# Altium schematic sheet mount_board.SchDoc
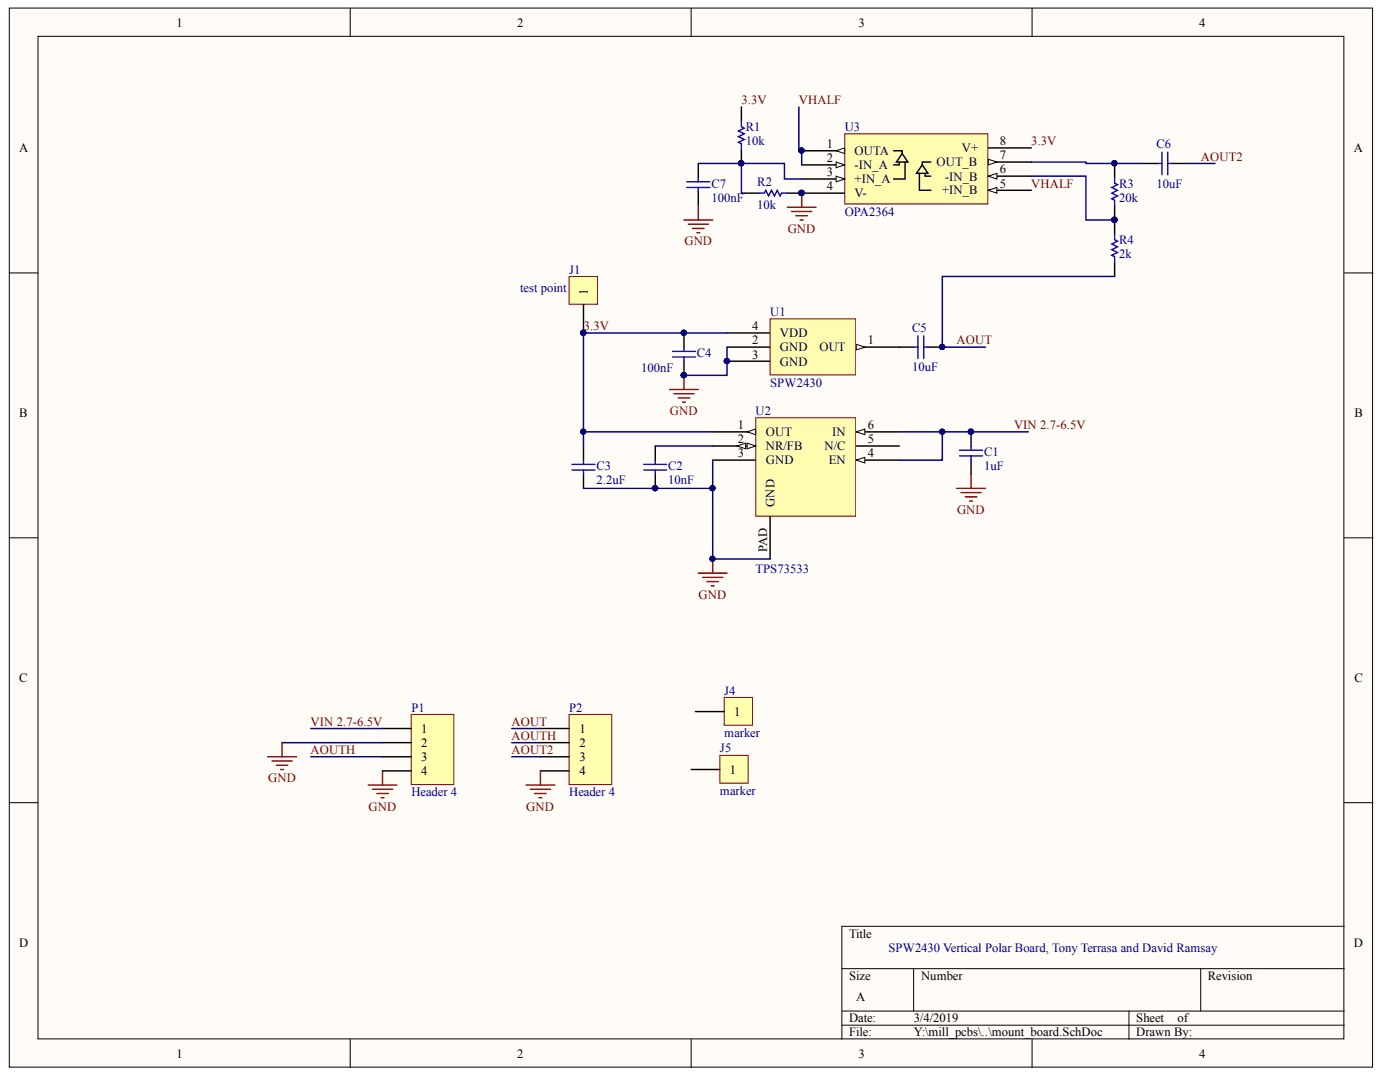
[diagram p0: mount_board.SchDoc]

## Parts
C1  1uF  [0603C]
C2  10nF  [0603C]
C3  2.2uF  [0603C]
C4  100nF  [0603C]
C5  10uF  [0603C]
C6  10uF  [0603C]
C7  100nF  [0603C]
J1  test point  [TEST POINT SMD SMALL]
J4  marker  [TEST POINT SMD SUPER SMALL]
J5  marker  [TEST POINT SMD SUPER SMALL]
P1  Header 4  [HDR1X4]
P2  Header 4  [HDR1X4]
R1  10k  [0603R]
R2  10k  [0603R]
R3  20k  [0603R]
R4  2k  [0603R]
U1  SPW2430  [MEMS MIC 4-pad]
U2  TPS73533  [SON_6LEAD_TI_TPS]
U3  OPA2364  [SOIC8]

## Nets
GND: C1.1 C2.1 C3.1 C4.1 C7.1 P1.2 P1.4 P2.4 R2.1 U1.2(GND) U1.3(GND) U2.3(GND) U2.PAD(GND) U3.4(V-)
3.3V: C3.2 C4.2 J1.1 R1.1 U1.4(VDD) U2.1(OUT) U3.8(V+)
AOUT: C5.1 P2.1 R4.2
AOUT2: C6.1 P2.3
AOUTH: P1.3 P2.2
VHALF: U3.1(OUTA) U3.2(-IN_A) U3.5(+IN_B)
VIN 2.7-6.5V: C1.2 P1.1 U2.4(EN) U2.6(IN)
NetC2_2: C2.2 U2.2(NR/FB)
NetC5_2: C5.2 U1.1(OUT)
NetC6_2: C6.2 R3.1 U3.7(OUT_B)
NetC7_2: C7.2 R1.2 R2.2 U3.3(+IN_A)
NetR3_2: R3.2 R4.1 U3.6(-IN_B)

Not connected: J4 1; J5 1; U2 5(N/C)

## Library data of the parts
C1-C7 = Capacitor: Component Kind: Standard; Simulation: CAP; Library Name: bdm.SchLib
J1 J4 J5 = CON1: Connector; MPN: DNP; MYPN: J-TP-PWRTAP-0603; Component Kind: Standard; VENDOR: DNP; Library Name: bunnie.SchLib
P1 P2 = Header 4: Header, 4-Pin; Component Kind: Standard; LatestRevisionDate: 17-Jul-2002; LatestRevisionNote: Re-released for DXP Platform.; Library Name: Miscellaneous Connectors.IntLib; Signal Integrity: Connector; Publisher: Altium Limited
R1-R4 = Resistor: Component Kind: Standard; Simulation: RESISTOR; Library Name: bdm.SchLib
U1 = SPW2430HR5H-B: Knowles Analog Omni MEMS Microphone; Component Kind: Standard; Library Name: Schematic Components.SchLib
U2 = TPS73533: TPS 500mA LDO, 3.3V; Component Kind: Standard; Library Name: Schematic Components.SchLib
U3 = OPA2364: TI 1.8-5V Op Amp, 7MHz GBW; Component Kind: Standard; Library Name: Schematic Components.SchLib
